# Altium schematic sheet Schematic Prints("All Documents",Physical)
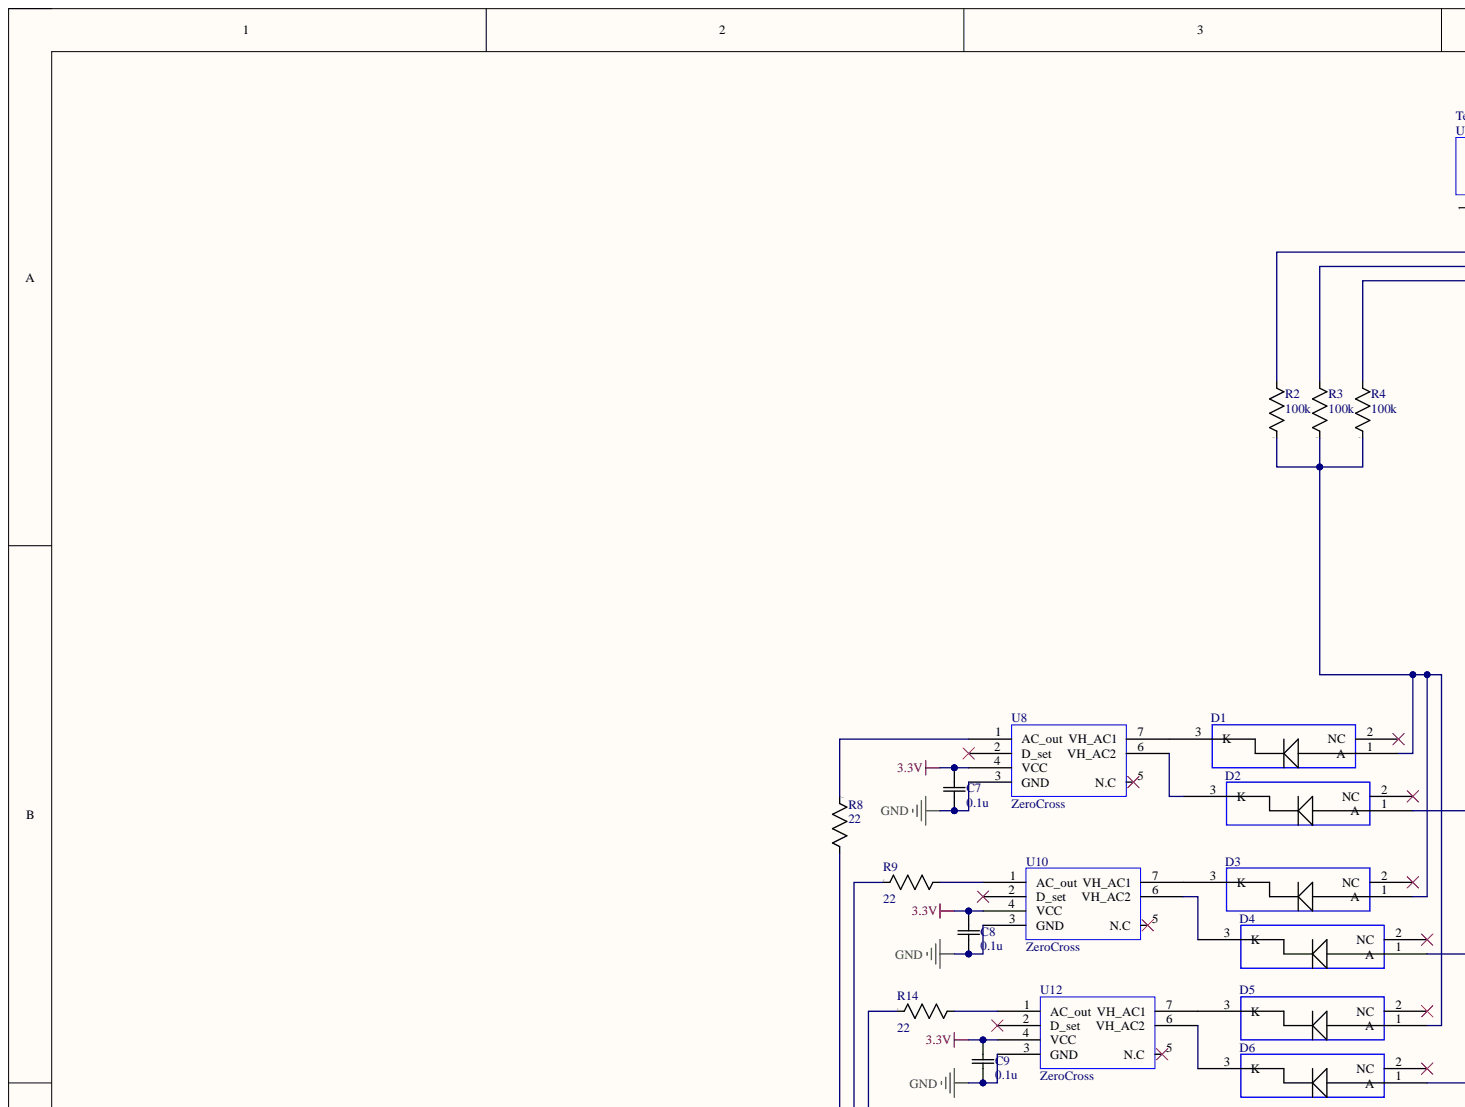
[diagram p0: Schematic Prints("All Documents",Physical), top left]
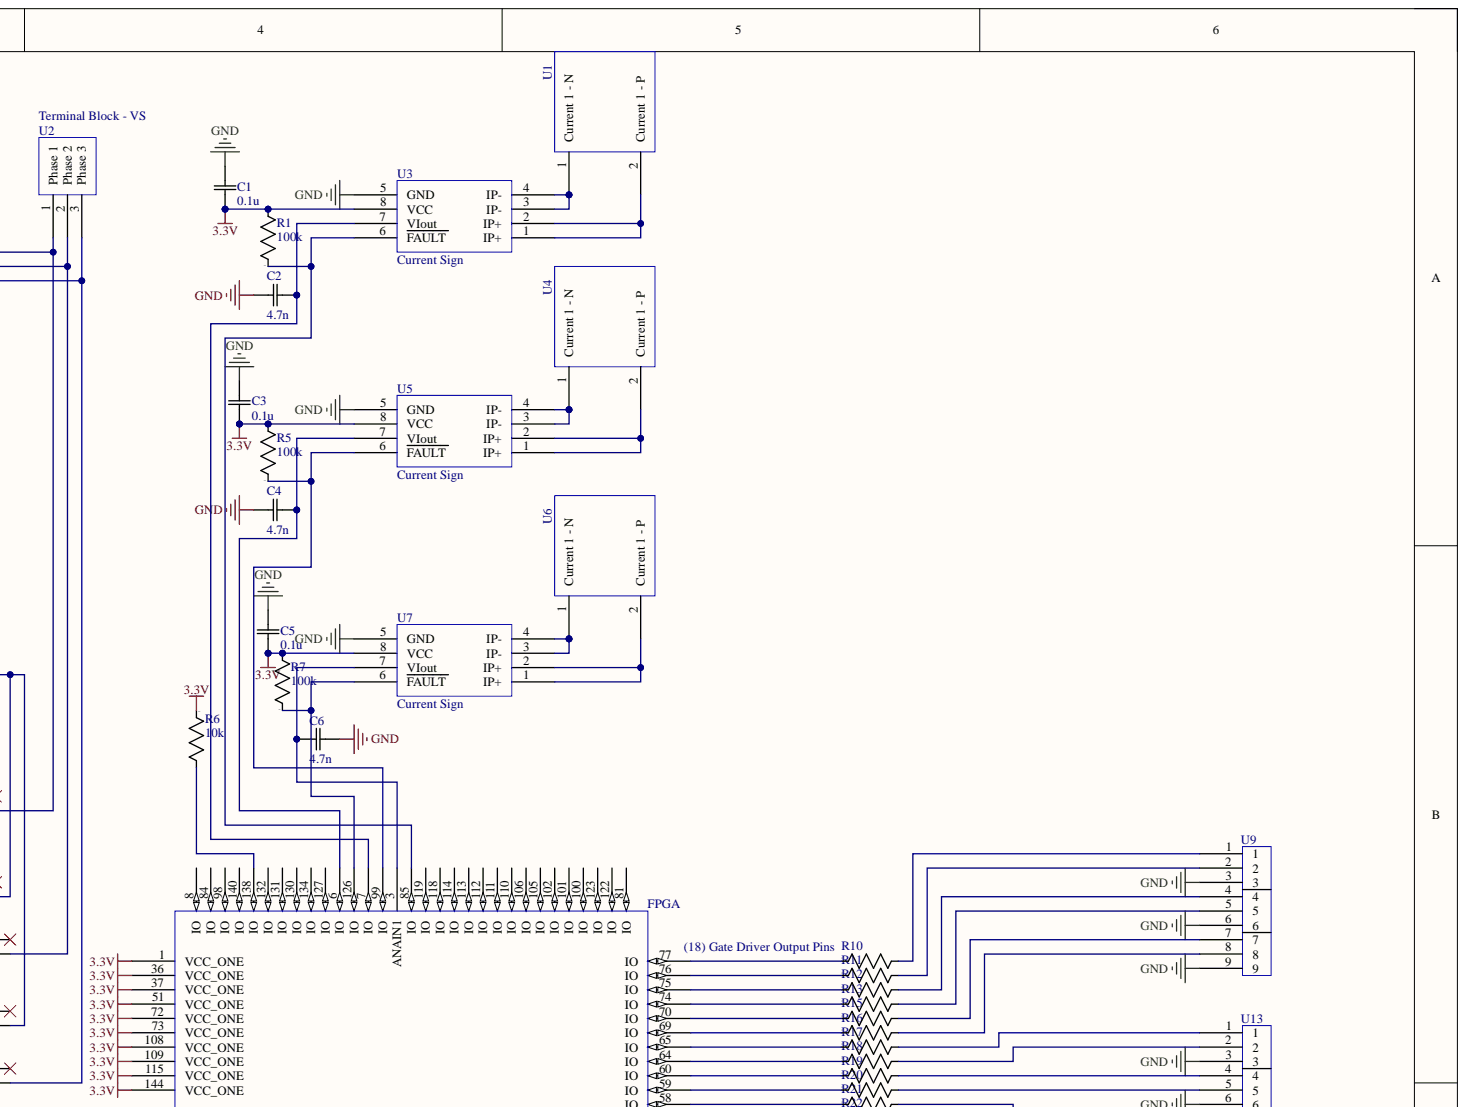
[diagram p1: Schematic Prints("All Documents",Physical), top right]
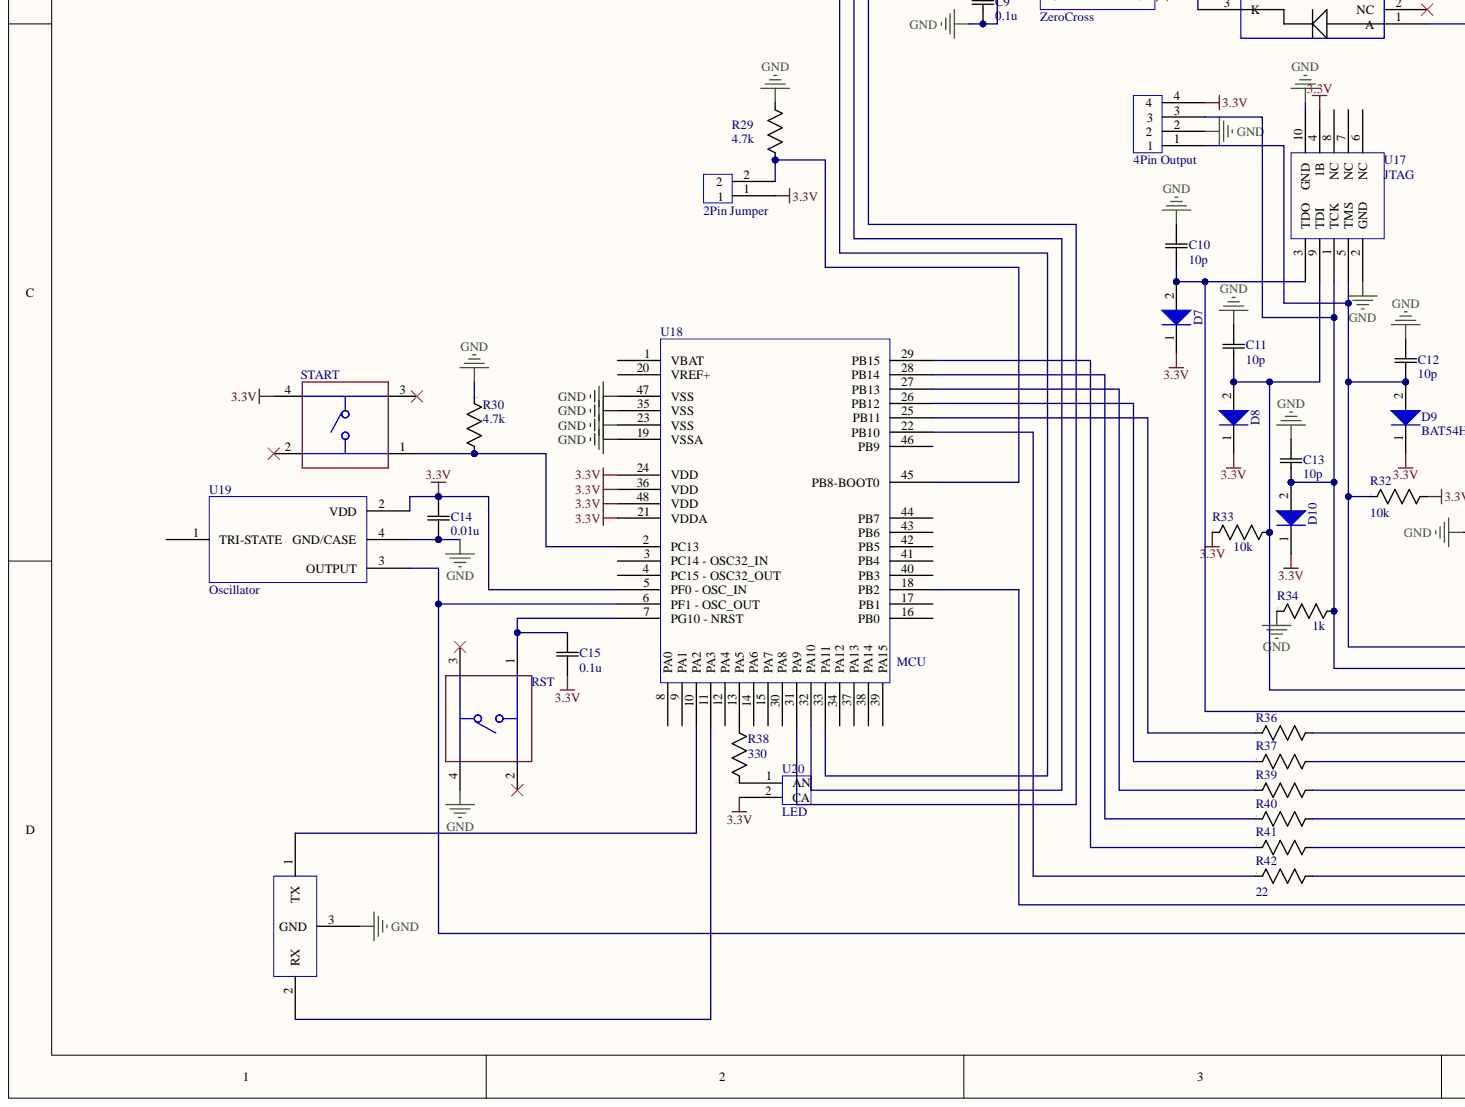
[diagram p2: Schematic Prints("All Documents",Physical), bottom left]
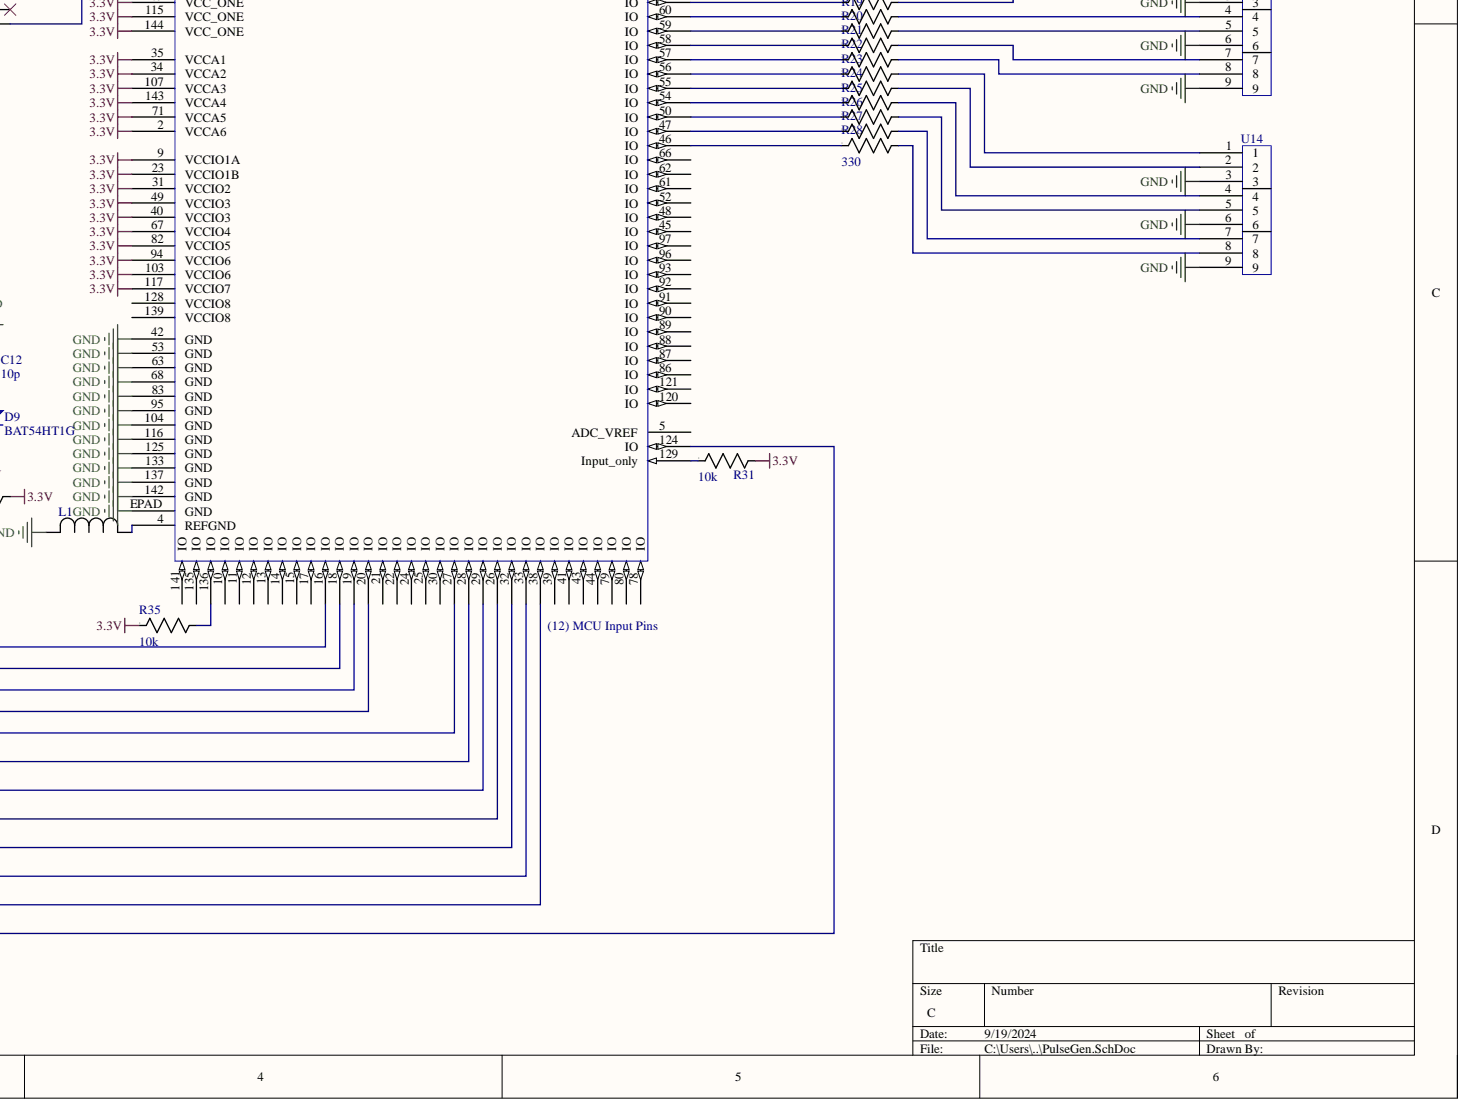
[diagram p3: Schematic Prints("All Documents",Physical), bottom right]

## Parts
C1  0.1u  [Resistor1]
C2  4.7n  [Resistor1]
C3  0.1u  [Resistor1]
C4  4.7n  [Resistor1]
C5  0.1u  [Resistor1]
C6  4.7n  [Resistor1]
C7  0.1u  [Resistor1]
C8  0.1u  [Resistor1]
C9  0.1u  [Resistor1]
C10  10p  [Resistor1]
C11  10p  [Resistor1]
C12  10p  [Resistor1]
C13  10p  [Resistor1]
C14  0.01u  [Resistor1]
C15  0.1u  [Resistor1]
C16
C17
CR1
D1  Diode  [Diode1]  (value not drawn)
D2  Diode  [Diode1]  (value not drawn)
D3  Diode  [Diode1]  (value not drawn)
D4  Diode  [Diode1]  (value not drawn)
D5  Diode  [Diode1]  (value not drawn)
D6  Diode  [Diode1]  (value not drawn)
D7  BAT54HT1G  [ONSC-SOD-323-2-477-02_V]
D8  BAT54HT1G  [ONSC-SOD-323-2-477-02_V]
D9  BAT54HT1G  [ONSC-SOD-323-2-477-02_V]
D10  BAT54HT1G  [ONSC-SOD-323-2-477-02_V]
L1  Ferrite Bead  [Resistor1]  (value not drawn)
R1  100k  [Resistor1]
R2  100k  [Resistor1]
R3  100k  [Resistor1]
R4  100k  [Resistor1]
R5  100k  [Resistor1]
R6  10k  [Resistor1]
R7  100k  [Resistor1]
R8  22  [Resistor1]
R9  22  [Resistor1]
R10  330  [Resistor1]  (value not drawn)
R11  330  [Resistor1]  (value not drawn)
R12  330  [Resistor1]  (value not drawn)
R13  330  [Resistor1]  (value not drawn)
R14  22  [Resistor1]
R15  330  [Resistor1]  (value not drawn)
R16  330  [Resistor1]  (value not drawn)
R17  330  [Resistor1]  (value not drawn)
R18  330  [Resistor1]  (value not drawn)
R19  330  [Resistor1]  (value not drawn)
R20  330  [Resistor1]  (value not drawn)
R21  330  [Resistor1]  (value not drawn)
R22  330  [Resistor1]  (value not drawn)
R23  330  [Resistor1]  (value not drawn)
R24  330  [Resistor1]  (value not drawn)
R25  330  [Resistor1]  (value not drawn)
R26  330  [Resistor1]  (value not drawn)
R27  330  [Resistor1]  (value not drawn)
R28  330  [Resistor1]
R29  4.7k  [Resistor1]
R30  4.7k  [Resistor1]
R31  10k  [Resistor1]
R32  10k  [Resistor1]
R33  10k  [Resistor1]
R34  1k  [Resistor1]
R35  10k  [Resistor1]
R36  22  [Resistor1]  (value not drawn)
R37  22  [Resistor1]  (value not drawn)
R38  330  [Resistor1]
R39  22  [Resistor1]  (value not drawn)
R40  22  [Resistor1]  (value not drawn)
R41  22  [Resistor1]  (value not drawn)
R42  22  [Resistor1]
RST  Button  [Button]  (value not drawn)
START  Button  [Button]  (value not drawn)
U1  Terminal Block - CS  [Terminal Block - CS]  (value not drawn)
U2  Terminal Block - VS  [Terminal Block - VS]
U3  Current Sign  [CurrentSign4]
U4  Terminal Block - CS  [Terminal Block - CS]  (value not drawn)
U5  Current Sign  [CurrentSign4]
U6  Terminal Block - CS  [Terminal Block - CS]  (value not drawn)
U7  Current Sign  [CurrentSign4]
U8  ZeroCross  [ZeroCross1]
U9  FPGA Output  [FPGA Output]  (value not drawn)
U10  ZeroCross  [ZeroCross1]
U11  FPGA  [FPGA2]
U12  ZeroCross  [ZeroCross1]
U13  FPGA Output  [FPGA Output]  (value not drawn)
U14  FPGA Output  [FPGA Output]  (value not drawn)
U15  4Pin Output  [4Pin Output]
U16  2Pin Jumper  [2Pin Jumper]
U17  JTAG  [JTAG]
U18  MCU  [MCU2]
U19  Oscillator  [Oscillator]
U20  LED  [Resistor1]
U21  UART  [UART]  (value not drawn)
U22

## Nets
3.3V: C1.1 C3.1 C5.1 C7.2 C8.2 C9.2 C14.2 C15.1 C17.2 CR1.2 CR1.4 D7.1 D8.1 D9.1 D10.1 R1.2 R5.2 R6. R7.2 R31.2 R32.2 R33. R35. START.4 U3.8(VCC) U5.8(VCC) U7.8(VCC) U8.4(VCC) U10.4(VCC) U11.1(VCC_ONE) U11.2(VCCA6) U11.9(VCCIO1A) U11.23(VCCIO1B) U11.31(VCCIO2) U11.34(VCCA2) U11.35(VCCA1) U11.36(VCC_ONE) U11.37(VCC_ONE) U11.40(VCCIO3) U11.49(VCCIO3) U11.51(VCC_ONE) U11.67(VCCIO4) U11.71(VCCA5) U11.72(VCC_ONE) U11.73(VCC_ONE) U11.82(VCCIO5) U11.94(VCCIO6) U11.103(VCCIO6) U11.107(VCCA3) U11.108(VCC_ONE) U11.109(VCC_ONE) U11.115(VCC_ONE) U11.117(VCCIO7) U11.143(VCCA4) U11.144(VCC_ONE) U12.4(VCC) U15.4 U16.1 U17.4(1B) U18.5(PF0_-_OSC_IN) U18.21(VDDA) U18.24(VDD) U18.36(VDD) U18.48(VDD) U19.2(VDD) U20.2
5V: C16.2 CR1.3 U22.1
GND: C1.2 C2.2 C3.2 C4.1 C5.2 C6.2 C7.1 C8.1 C9.1 C10.1 C11.2 C12.2 C13.1 C14.1 C16.1 C17.1 CR1.1 L1.2 R29.2 R30. R34. RST.4 U3.5(GND) U5.5(GND) U7.5(GND) U8.3(GND) U9.3 U9.6 U9.9 U10.3(GND) U11.42(GND) U11.53(GND) U11.63(GND) U11.68(GND) U11.83(GND) U11.95(GND) U11.104(GND) U11.116(GND) U11.125(GND) U11.133(GND) U11.137(GND) U11.142(GND) U11.EPAD(GND) U12.3(GND) U13.3 U13.6 U13.9 U14.3 U14.6 U14.9 U15.2 U17.2(GND) U17.10(GND) U18.19(VSSA) U18.23(VSS) U18.35(VSS) U18.47(VSS) U19.4(GND/CASE) U21.3 U22.2
NetR1: R1. U3.6(FAULT) U11.85(IO)
NetR5: R5. U5.6(FAULT) U11.99(IO)
NetR7: R7. U7.6(FAULT) U11.126(IO)
NetR8: R8. U8.1(AC_out)
NetR9: R9. U18.32(PA10)
NetR10: R10. U11.77(IO)
NetR11: R11. U11.76(IO)
NetR12: R12. U11.75(IO)
NetR13: R13. U11.74(IO)
NetR14: R14. U18.31(PA9)
NetR15: R15. U11.70(IO)
NetR16: R16. U11.69(IO)
NetR17: R17. U11.65(IO)
NetR18: R18. U11.64(IO)
NetR19: R19. U11.60(IO)
NetR20: R20. U11.59(IO)
NetR21: R21. U11.58(IO)
NetR22: R22. U11.57(IO)
NetR23: R23. U11.56(IO)
NetR24: R24. U11.55(IO)
NetR25: R25. U11.54(IO)
NetR26: R26. U11.50(IO)
NetR27: R27. U11.47(IO)
NetR28: R28. U11.46(IO)
NetR29: R29. U16.2 U18.45(PB8-BOOT0)
NetR31: R31. U11.129(Input_only)
NetR36: R36. U18.25(PB11)
NetR37: R37. U18.26(PB12)
NetR38: R38. U20.1
NetR39: R39. U18.27(PB13)
NetR40: R40. U18.28(PB14)
NetR41: R41. U18.29(PB15)
NetR42: R42. U18.22(PB10)
NetC2_1: C2.1 U3.7(VIout) U11.7(IO)
NetC4_2: C4.2 U5.7(VIout) U11.6(IO)
NetC6_1: C6.1 U7.7(VIout) U11.3(ANAIN1)
NetC10_2: C10.2 D7.2 U11.20(IO) U17.3(TDO)
NetC11_1: C11.1 D8.2 R33.2 U11.19(IO) U17.9(TDI)
NetC12_1: C12.1 D9.2 R32. U11.16(IO) U15.1 U17.5(TMS)
NetC13_2: C13.2 D10.2 R34.2 U11.18(IO) U15.3 U17.1(TCK)
NetC15_2: C15.2 RST.1 U18.7(PG10_-_NRST)
NetD1_1: D1.1 D3.1 D5.1 R2. R3. R4.
NetD1_2: D1.2
NetD1_3: D1.3 U8.7(VH_AC1)
NetD2_1: D2.1 R2.2 U2.1
NetD2_2: D2.2
NetD2_3: D2.3 U8.6(VH_AC2)
NetD3_2: D3.2
NetD3_3: D3.3 U10.7(VH_AC1)
NetD4_1: D4.1 R3.2 U2.2
NetD4_2: D4.2
NetD4_3: D4.3 U10.6(VH_AC2)
NetD5_2: D5.2
NetD5_3: D5.3 U12.7(VH_AC1)
NetD6_1: D6.1 R4.2 U2.3
NetD6_2: D6.2
NetD6_3: D6.3 U12.6(VH_AC2)
NetL1_1: L1.1 U11.4(REFGND)
NetR6_2: R6.2 U11.138(IO)
NetR8_2: R8.2 U18.33(PA11)
NetR9_2: R9.2 U10.1(AC_out)
NetR10_2: R10.2 U9.1
NetR11_2: R11.2 U9.2
NetR12_2: R12.2 U9.4
NetR13_2: R13.2 U9.5
NetR14_2: R14.2 U12.1(AC_out)
NetR15_2: R15.2 U9.7
NetR16_2: R16.2 U9.8
NetR17_2: R17.2 U13.1
NetR18_2: R18.2 U13.2
NetR19_2: R19.2 U13.4
NetR20_2: R20.2 U13.5
NetR21_2: R21.2 U13.7
NetR22_2: R22.2 U13.8
NetR23_2: R23.2 U14.1
NetR24_2: R24.2 U14.2
NetR25_2: R25.2 U14.4
NetR26_2: R26.2 U14.5
NetR27_2: R27.2 U14.7
NetR28_2: R28.2 U14.8
NetR30_2: R30.2 START.1 U18.2(PC13)
NetR35_2: R35.2 U11.136(IO)
NetR36_2: R36.2 U11.27(IO)
NetR37_2: R37.2 U11.28(IO)
NetR38_2: R38.2 U18.13(PA5)
NetR39_2: R39.2 U11.29(IO)
NetR40_2: R40.2 U11.26(IO)
NetR41_2: R41.2 U11.32(IO)
NetR42_2: R42.2 U11.33(IO)
NetRST_2: RST.2
NetRST_3: RST.3
NetSTART_2: START.2
NetSTART_3: START.3
NetU1_1: U1.1 U3.3(IP-) U3.4(IP-)
NetU1_2: U1.2 U3.1(IP+) U3.2(IP+)
NetU4_1: U4.1 U5.3(IP-) U5.4(IP-)
NetU4_2: U4.2 U5.1(IP+) U5.2(IP+)
NetU6_1: U6.1 U7.3(IP-) U7.4(IP-)
NetU6_2: U6.2 U7.1(IP+) U7.2(IP+)
NetU8_2: U8.2(D_set)
NetU8_5: U8.5(N.C)
NetU10_2: U10.2(D_set)
NetU10_5: U10.5(N.C)
NetU11_5: U11.5(ADC_VREF)
NetU11_8: U11.8(IO)
NetU11_10: U11.10(IO)
NetU11_11: U11.11(IO)
NetU11_12: U11.12(IO)
NetU11_13: U11.13(IO)
NetU11_14: U11.14(IO)
NetU11_15: U11.15(IO)
NetU11_17: U11.17(IO)
NetU11_21: U11.21(IO)
NetU11_22: U11.22(IO)
NetU11_24: U11.24(IO)
NetU11_25: U11.25(IO)
NetU11_30: U11.30(IO)
NetU11_38: U11.38(IO) U18.18(PB2)
NetU11_39: U11.39(IO)
NetU11_41: U11.41(IO)
NetU11_43: U11.43(IO)
NetU11_44: U11.44(IO)
NetU11_45: U11.45(IO)
NetU11_48: U11.48(IO)
NetU11_52: U11.52(IO)
NetU11_61: U11.61(IO)
NetU11_62: U11.62(IO)
NetU11_66: U11.66(IO)
NetU11_78: U11.78(IO)
NetU11_79: U11.79(IO)
NetU11_80: U11.80(IO)
NetU11_81: U11.81(IO)
NetU11_84: U11.84(IO)
NetU11_86: U11.86(IO)
NetU11_87: U11.87(IO)
NetU11_88: U11.88(IO)
NetU11_89: U11.89(IO)
NetU11_90: U11.90(IO)
NetU11_91: U11.91(IO)
NetU11_92: U11.92(IO)
NetU11_93: U11.93(IO)
NetU11_96: U11.96(IO)
NetU11_97: U11.97(IO)
NetU11_98: U11.98(IO)
NetU11_100: U11.100(IO)
NetU11_101: U11.101(IO)
NetU11_102: U11.102(IO)
NetU11_105: U11.105(IO)
NetU11_106: U11.106(IO)
NetU11_110: U11.110(IO)
NetU11_111: U11.111(IO)
NetU11_112: U11.112(IO)
NetU11_113: U11.113(IO)
NetU11_114: U11.114(IO)
NetU11_118: U11.118(IO)
NetU11_119: U11.119(IO)
NetU11_120: U11.120(IO)
NetU11_121: U11.121(IO)
NetU11_122: U11.122(IO)
NetU11_123: U11.123(IO)
NetU11_124: U11.124(IO) U18.6(PF1_-_OSC_OUT) U19.3(OUTPUT)
NetU11_127: U11.127(IO)
NetU11_128: U11.128(VCCIO8)
NetU11_130: U11.130(IO)
NetU11_131: U11.131(IO)
NetU11_132: U11.132(IO)
NetU11_134: U11.134(IO)
NetU11_135: U11.135(IO)
NetU11_139: U11.139(VCCIO8)
NetU11_140: U11.140(IO)
NetU11_141: U11.141(IO)
NetU12_2: U12.2(D_set)
NetU12_5: U12.5(N.C)
NetU17_6: U17.6(NC)
NetU17_7: U17.7(NC)
NetU17_8: U17.8(NC)
NetU18_1: U18.1(VBAT)
NetU18_3: U18.3(PC14_-_OSC32_IN)
NetU18_4: U18.4(PC15_-_OSC32_OUT)
NetU18_8: U18.8(PA0)
NetU18_9: U18.9(PA1)
NetU18_10: U18.10(PA2) U21.1
NetU18_11: U18.11(PA3) U21.2
NetU18_12: U18.12(PA4)
NetU18_14: U18.14(PA6)
NetU18_15: U18.15(PA7)
NetU18_16: U18.16(PB0)
NetU18_17: U18.17(PB1)
NetU18_20: U18.20(VREF+)
NetU18_30: U18.30(PA8)
NetU18_34: U18.34(PA12)
NetU18_37: U18.37(PA13)
NetU18_38: U18.38(PA14)
NetU18_39: U18.39(PA15)
NetU18_40: U18.40(PB3)
NetU18_41: U18.41(PB4)
NetU18_42: U18.42(PB5)
NetU18_43: U18.43(PB6)
NetU18_44: U18.44(PB7)
NetU18_46: U18.46(PB9)
NetU19_1: U19.1(TRI-STATE)

## Library data of the parts
C1 C3 C5 C7-C9 C15 = Capacitor0.1u: C0805C104K1RAC7800; Mfr_Name: Murata; Component Kind: Standard; Library Name: PulseGenSymLib.SchLib
C2 C4 C6 = Capacitor4.7n: C0805C472K1GEC7800; Mfr_Name: Murata; Component Kind: Standard; Library Name: PulseGenSymLib.SchLib
C10-C13 = Capacitor10p: C0805C103J1GEC7800; Mfr_Name: Murata; Component Kind: Standard; Library Name: PulseGenSymLib.SchLib
C14 = Capacitor0.01u: C0805C103J1GEC7800; Mfr_Name: Murata; Component Kind: Standard; Library Name: PulseGenSymLib.SchLib
D1-D6 = Diode: Mfr_Name: onsemi; Type: Diodes - Rectifiers, Single; Component Kind: Standard; Library Name: PulseGenSymLib.SchLib; RefDes: RefDes
D7-D10 = CMP-1055-00462-1: Schottky Barrier Diode, 2-Pin SOD-323, Pb-Free, Tape and Reel; Manufacturer: On Semiconductor; Configuration: Single; IFSM Max (A): 0.6; trr Max (ns): 5; Component Kind: Standard; ComponentLink1Description: Manufacturer URL; ComponentLink1URL: http://www.onsemi.com/; ComponentLink2Description: Datasheet; ComponentLink2URL: http://www.onsemi.cn/pub_link/Collateral/BAT54HT1-D.PDF; ComponentLink3Description: Package Specification; ComponentLink3URL: http://www.onsemi.com/pub_link/Collateral/477-02.PDF.1; DatasheetVersion: Rev. 6; Library Name: Altium Content Vault; Mounting Technology: Surface Mount; PackageDescription: 2-Pin SOD, Body 1.7 x 1.25 mm; PackageReference: SOD-323-2-477-02; PackageVersion: Rev. H, 03/2007; Packing: Tape and Reel; PartNumber: BAT54HT1G; RoHS: TRUE
L1 = Ferrite Bead: BLM21BB050SN1D; Mfr_Name: TDK; Component Kind: Standard; Library Name: PulseGenSymLib.SchLib
R1-R5 R7 = Resistor100k: RMCF0805FT100K; Mfr_Name: Stackpole International; Component Kind: Standard; Library Name: PulseGenSymLib.SchLib
R6 R31-R33 R35 = Resistor10k: RMCF0805FT4K70; Mfr_Name: Stackpole International; Component Kind: Standard; Library Name: PulseGenSymLib.SchLib
R8 R9 R14 R36 R37 R39-R42 = Resistor22: RMCF0603JT22R0; Mfr_Name: Stackpole International; Component Kind: Standard; Library Name: PulseGenSymLib.SchLib
R10-R13 R15-R28 R38 = Resistor330: RMCF0805FT330R; Mfr_Name: Stackpole International; Component Kind: Standard; Library Name: PulseGenSymLib.SchLib
R29 R30 = Resistor4.7k: RMCF0805FT4K70; Mfr_Name: Stackpole International; Component Kind: Standard; Library Name: PulseGenSymLib.SchLib
R34 = Resistor1k: RMCF0805FT4K70; Mfr_Name: Stackpole International; Component Kind: Standard; Library Name: PulseGenSymLib.SchLib
RST START = Button: SW_TS02-66-60-BK-100-LCR-D; Availability: Unavailable; Component Kind: Standard; Library Name: PulseGenSymLib.SchLib; MP: TS02-66-60-BK-100-LCR-D; Package: None; Price: None
U1 U4 U6 = Terminal Block - CS: 8719; Component Kind: Standard; Library Name: PulseGenSymLib.SchLib
U2 = Terminal Block - VS: 8719; Component Kind: Standard; Library Name: PulseGenSymLib.SchLib
U3 U5 U7 = CurrentSign: ACS71240LLCBTR-010B3; Component Kind: Standard; Library Name: PulseGenSymLib.SchLib
U8 U10 U12 = ZeroCross: BM1Z003FJ-E2; Component Kind: Standard; Library Name: PulseGenSymLib.SchLib
U11 = FPGA: 10M04SAE144C8G; Component Kind: Standard; Library Name: PulseGenSymLib.SchLib
U17 = JTAG: JTAG 10 Pin Header; Component Kind: Standard; Library Name: PulseGenSymLib.SchLib
U18 = MCU: STM32G491CET6; Component Kind: Standard; Library Name: PulseGenSymLib.SchLib
U19 = Oscillator: ASE-48.000MHZ-L-C-T; Component Kind: Standard; Library Name: PulseGenSymLib.SchLib
U9 U13 U14 = FPGA Output: Component Kind: Standard; Library Name: PulseGenSymLib.SchLib
U15 = 4Pin Output: Component Kind: Standard; Library Name: PulseGenSymLib.SchLib
U16 = 2Pin Jumper: Component Kind: Standard; Library Name: PulseGenSymLib.SchLib
U20 = LED: Component Kind: Standard; Library Name: PulseGenSymLib.SchLib
U21 = UART: Component Kind: Standard; Library Name: PulseGenSymLib.SchLib
